# Altium schematic sheet CUP2.SchDoc(CUP2)
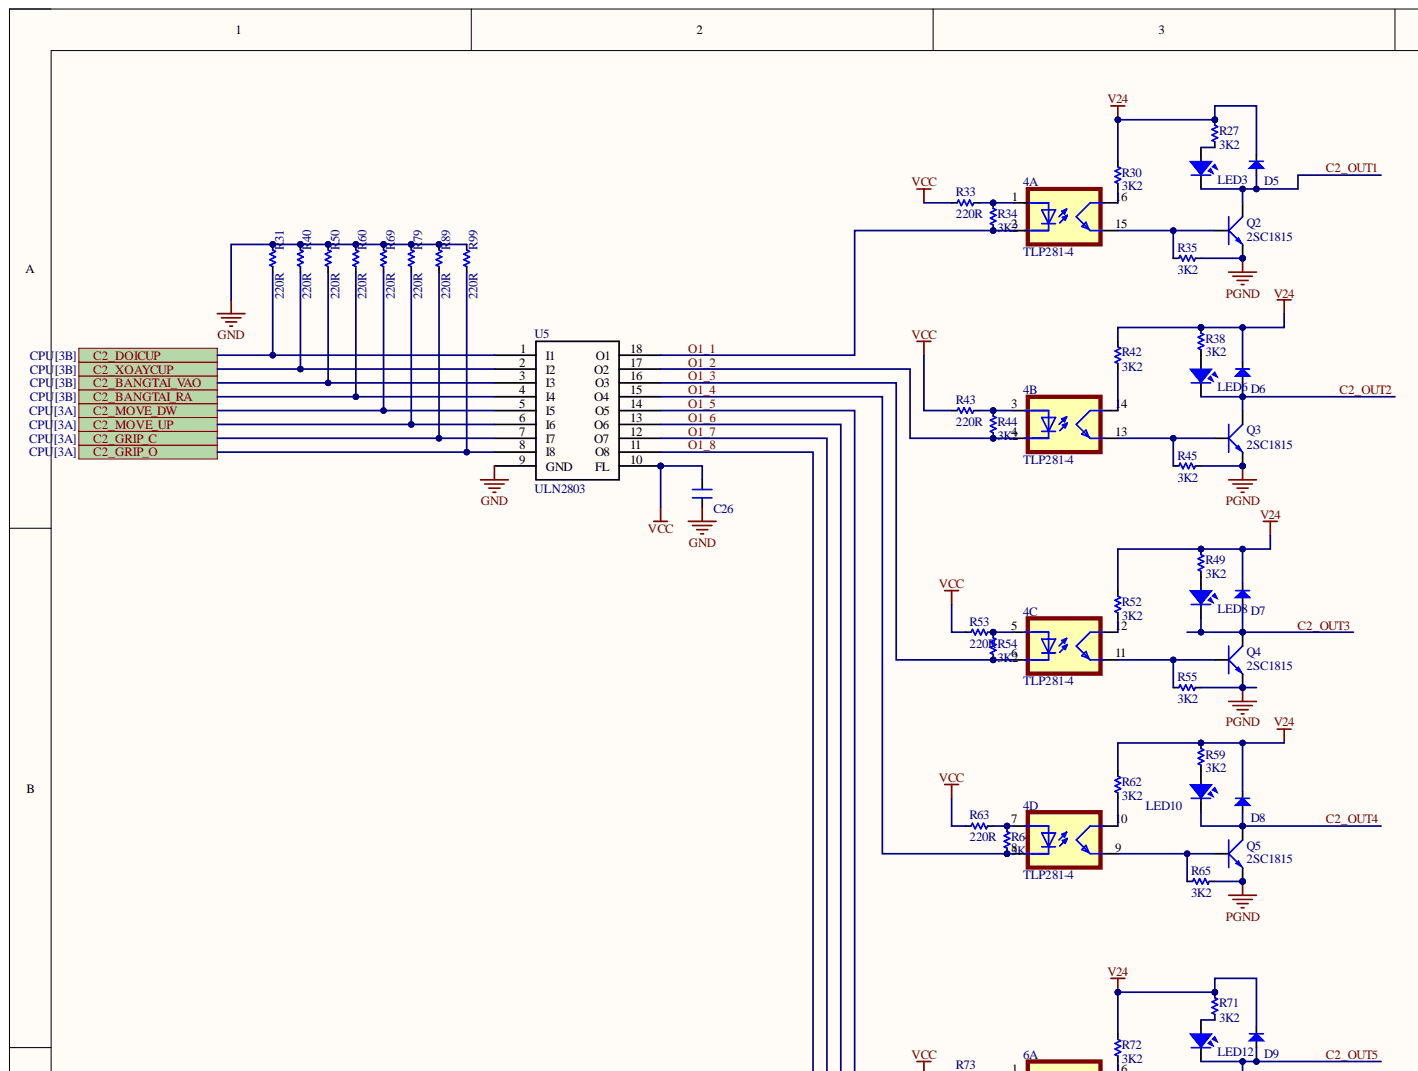
[diagram p0: CUP2.SchDoc, top left]
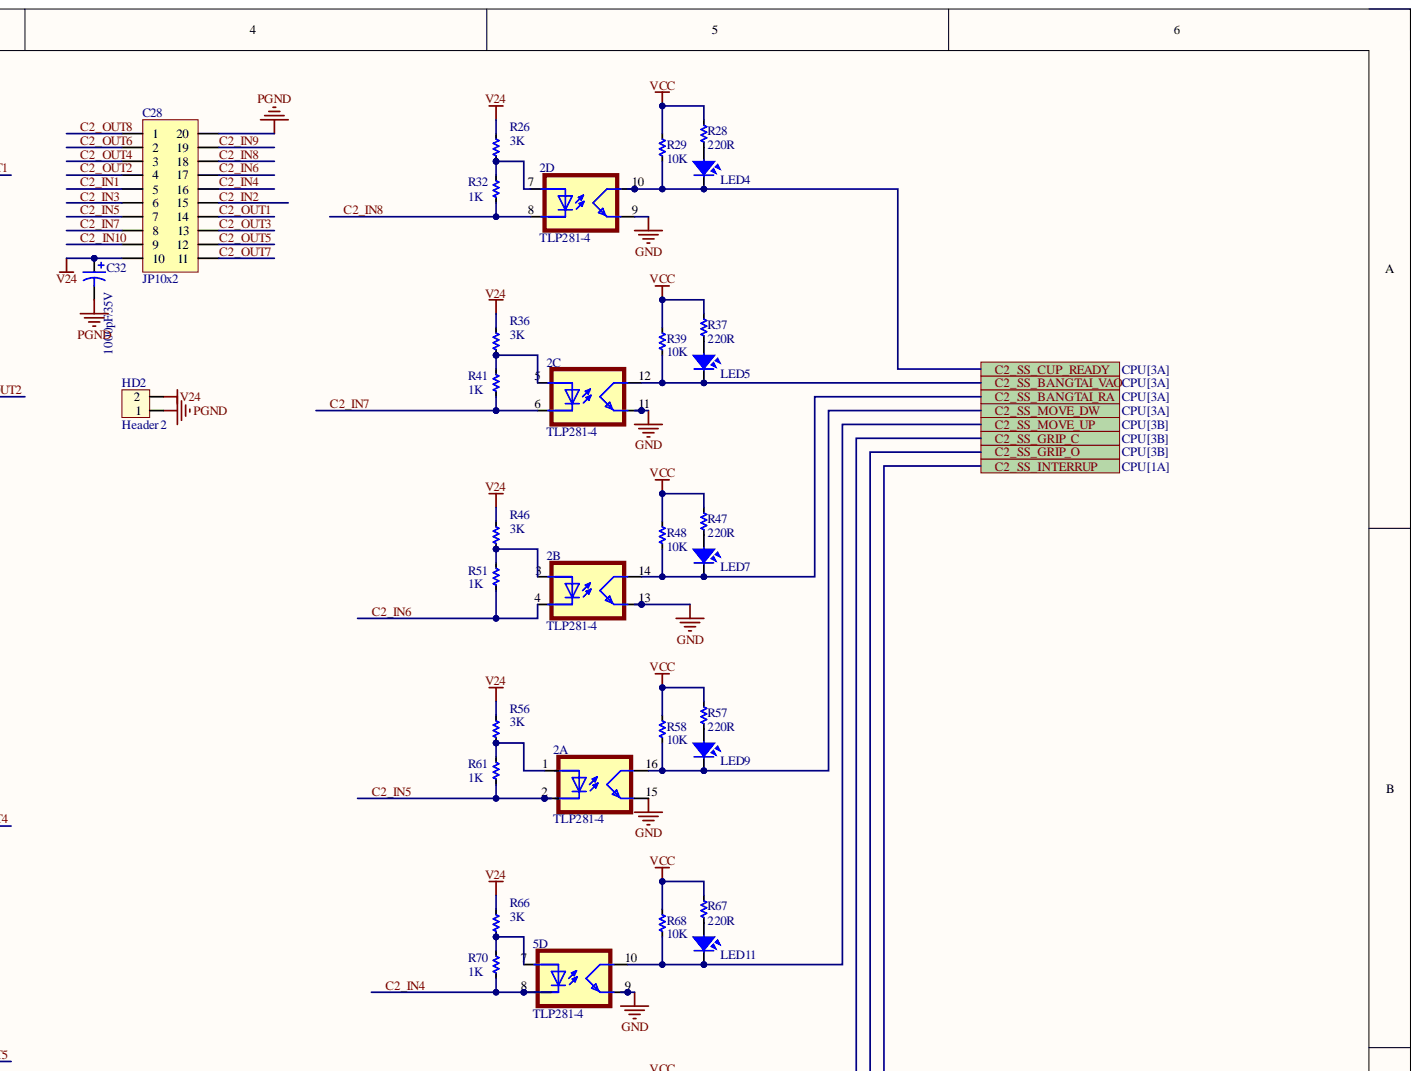
[diagram p1: CUP2.SchDoc, top right]
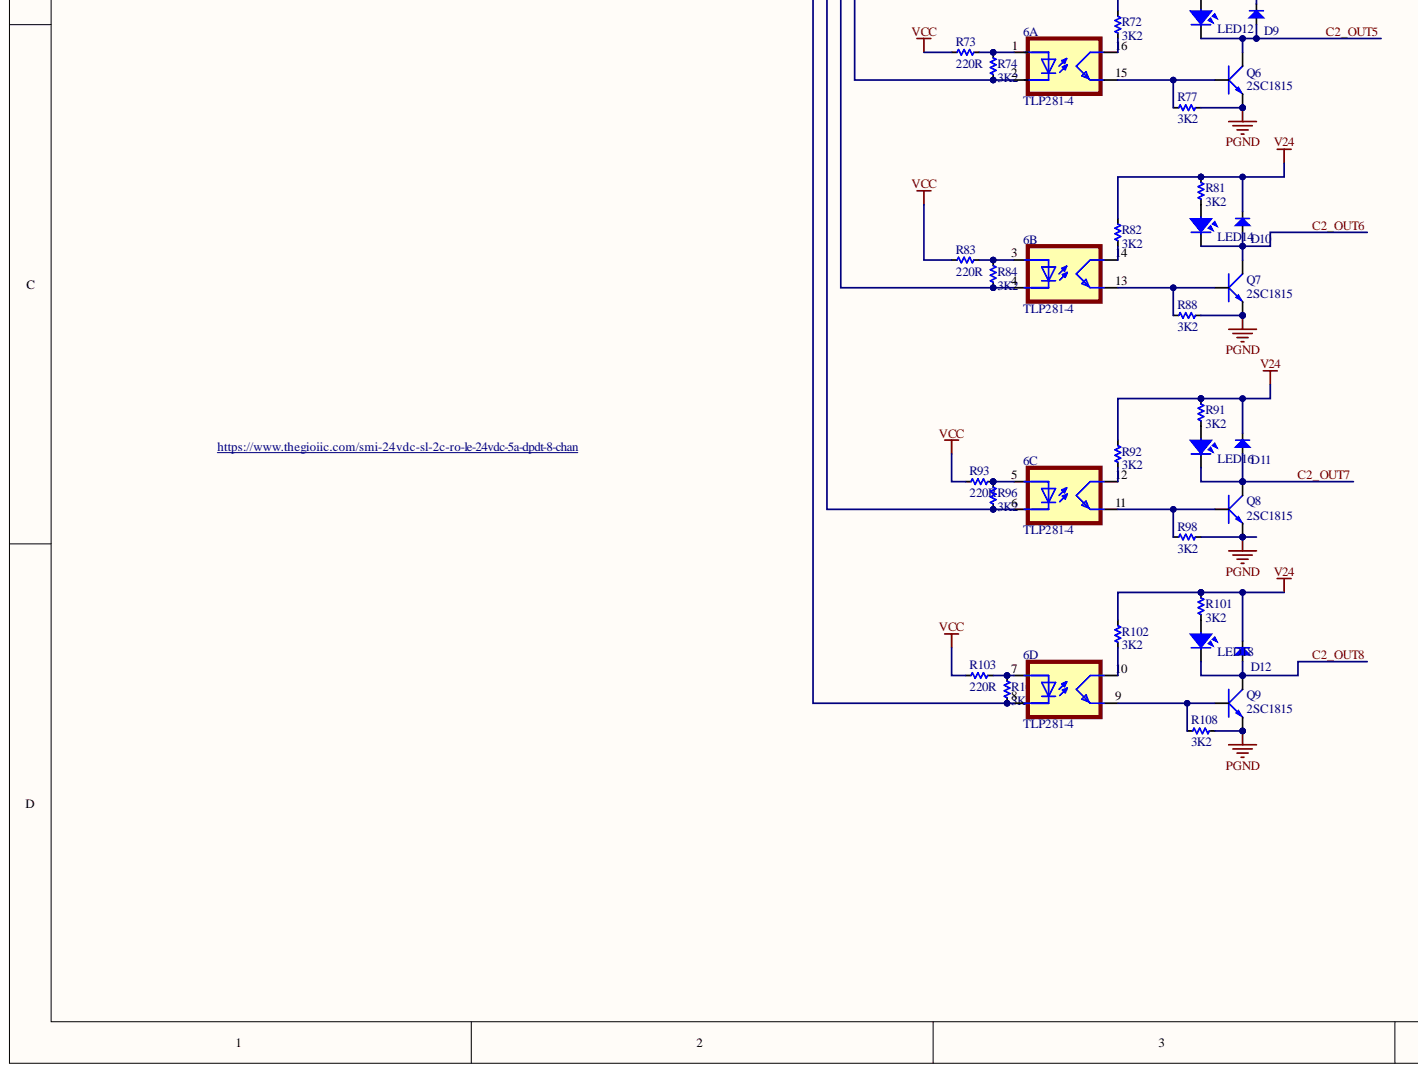
[diagram p2: CUP2.SchDoc, bottom left]
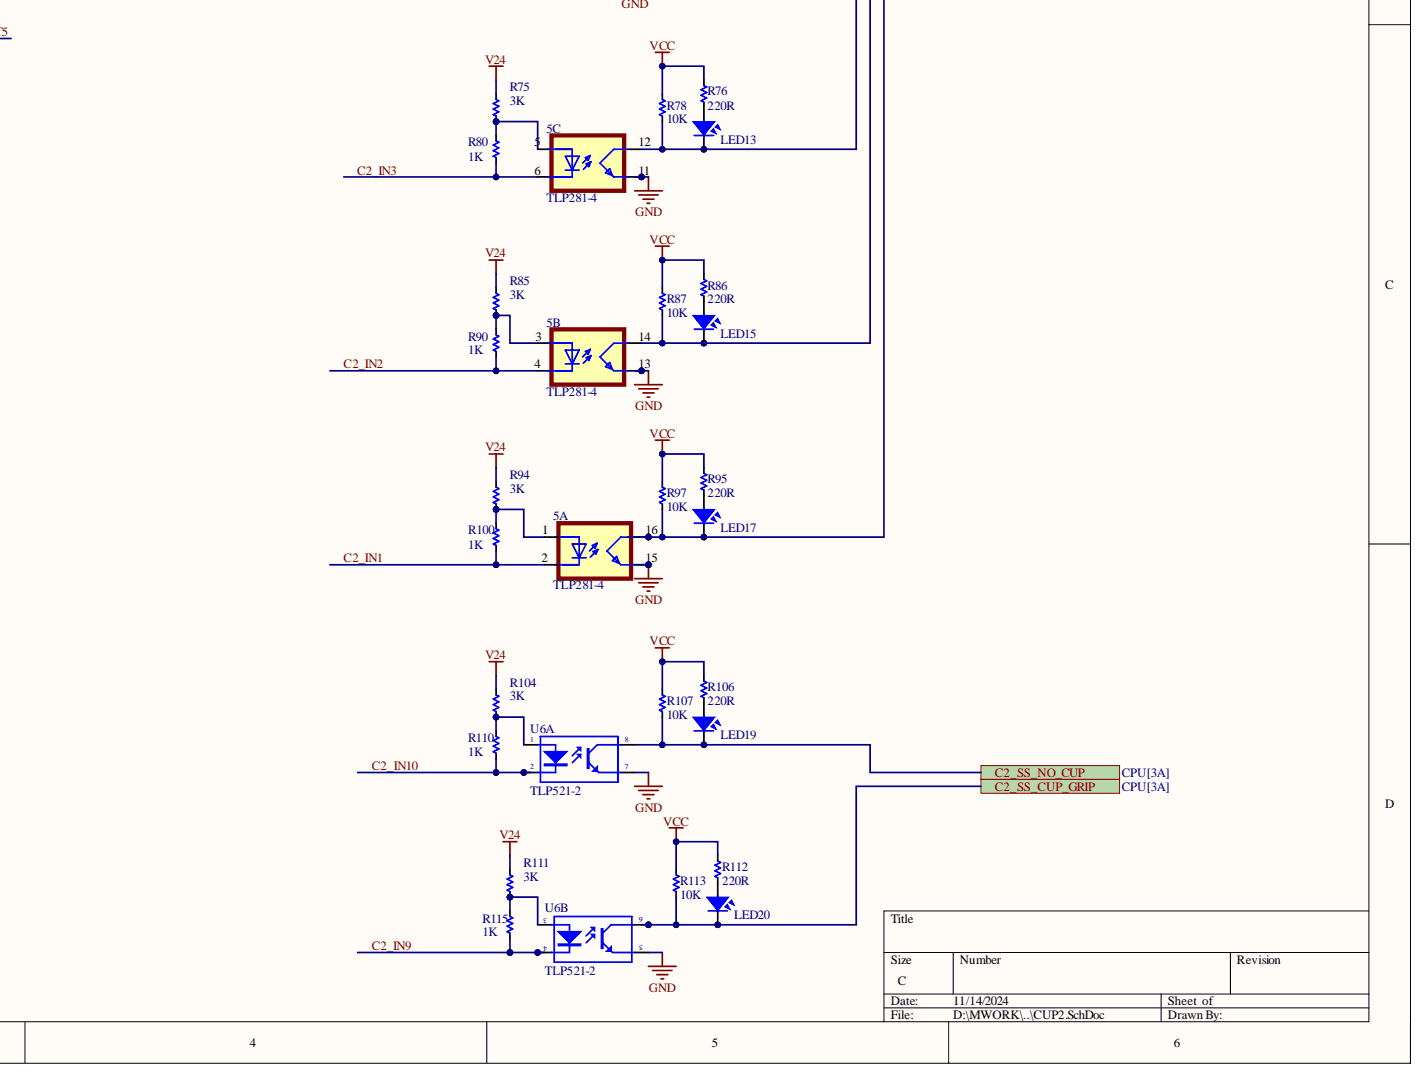
[diagram p3: CUP2.SchDoc, bottom right]

## Parts
2  TLP281-4  [SOP-16]  (parts A,B,C,D)
4  TLP281-4  [SOP-16]  (parts A,B,C,D)
5  TLP281-4  [SOP-16]  (parts A,B,C,D)
6  TLP281-4  [SOP-16]  (parts A,B,C,D)
C26  100nF  [CN-SMD-0805]  (value not drawn)
C28  JP10x2  [Connecter 5569]
C32  Cap Pol3  [CP2/5]  (value not drawn)
D5  Diode  [DIO-SMD-106-HayDung]  (value not drawn)
D6  Diode  [DIO-SMD-106-HayDung]  (value not drawn)
D7  Diode  [DIO-SMD-106-HayDung]  (value not drawn)
D8  Diode  [DIO-SMD-106-HayDung]  (value not drawn)
D9  Diode  [DIO-SMD-106-HayDung]  (value not drawn)
D10  Diode  [DIO-SMD-106-HayDung]  (value not drawn)
D11  Diode  [DIO-SMD-106-HayDung]  (value not drawn)
D12  Diode  [DIO-SMD-106-HayDung]  (value not drawn)
HD2  Header 2  [HED2T]
LED3  LED  [LED-0805-Blue]
LED4  LED  [LED-0805-Blue]
LED5  LED  [LED-0805-Blue]
LED6  LED  [LED-0805-Blue]
LED7  LED  [LED-0805-Blue]
LED8  LED  [LED-0805-Blue]
LED9  LED  [LED-0805-Blue]
LED10  LED  [LED-0805-Blue]
LED11  LED  [LED-0805-Blue]
LED12  LED  [LED-0805-Blue]
LED13  LED  [LED-0805-Blue]
LED14  LED  [LED-0805-Blue]
LED15  LED  [LED-0805-Blue]
LED16  LED  [LED-0805-Blue]
LED17  LED  [LED-0805-Blue]
LED18  LED  [LED-0805-Blue]
LED19  LED  [LED-0805-Blue]
LED20  LED  [LED-0805-Blue]
Q2  2SC1815  [SOT23-321]
Q3  2SC1815  [SOT23-321]
Q4  2SC1815  [SOT23-321]
Q5  2SC1815  [SOT23-321]
Q6  2SC1815  [SOT23-321]
Q7  2SC1815  [SOT23-321]
Q8  2SC1815  [SOT23-321]
Q9  2SC1815  [SOT23-321]
R26  3K  [RES-SMD-1206]
R27  3K2  [RES-SMD-0805]
R28  220R  [RES-SMD-0805]
R29  10K  [RES-SMD-0805]
R30  3K2  [RES-SMD-0805]
R31  220R  [RES-SMD-0805]
R32  1K  [RES-SMD-0805]
R33  220R  [RES-SMD-0805]
R34  3K2  [RES-SMD-0805]
R35  3K2  [RES-SMD-0805]
R36  3K  [RES-SMD-1206]
R37  220R  [RES-SMD-0805]
R38  3K2  [RES-SMD-0805]
R39  10K  [RES-SMD-0805]
R40  220R  [RES-SMD-0805]
R41  1K  [RES-SMD-0805]
R42  3K2  [RES-SMD-0805]
R43  220R  [RES-SMD-0805]
R44  3K2  [RES-SMD-0805]
R45  3K2  [RES-SMD-0805]
R46  3K  [RES-SMD-1206]
R47  220R  [RES-SMD-0805]
R48  10K  [RES-SMD-0805]
R49  3K2  [RES-SMD-0805]
R50  220R  [RES-SMD-0805]
R51  1K  [RES-SMD-0805]
R52  3K2  [RES-SMD-0805]
R53  220R  [RES-SMD-0805]
R54  3K2  [RES-SMD-0805]
R55  3K2  [RES-SMD-0805]
R56  3K  [RES-SMD-1206]
R57  220R  [RES-SMD-0805]
R58  10K  [RES-SMD-0805]
R59  3K2  [RES-SMD-0805]
R60  220R  [RES-SMD-0805]
R61  1K  [RES-SMD-0805]
R62  3K2  [RES-SMD-0805]
R63  220R  [RES-SMD-0805]
R64  3K2  [RES-SMD-0805]
R65  3K2  [RES-SMD-0805]
R66  3K  [RES-SMD-1206]
R67  220R  [RES-SMD-0805]
R68  10K  [RES-SMD-0805]
R69  220R  [RES-SMD-0805]
R70  1K  [RES-SMD-0805]
R71  3K2  [RES-SMD-0805]
R72  3K2  [RES-SMD-0805]
R73  220R  [RES-SMD-0805]
R74  3K2  [RES-SMD-0805]
R75  3K  [RES-SMD-1206]
R76  220R  [RES-SMD-0805]
R77  3K2  [RES-SMD-0805]
R78  10K  [RES-SMD-0805]
R79  220R  [RES-SMD-0805]
R80  1K  [RES-SMD-0805]
R81  3K2  [RES-SMD-0805]
R82  3K2  [RES-SMD-0805]
R83  220R  [RES-SMD-0805]
R84  3K2  [RES-SMD-0805]
R85  3K  [RES-SMD-1206]
R86  220R  [RES-SMD-0805]
R87  10K  [RES-SMD-0805]
R88  3K2  [RES-SMD-0805]
R89  220R  [RES-SMD-0805]
R90  1K  [RES-SMD-0805]
R91  3K2  [RES-SMD-0805]
R92  3K2  [RES-SMD-0805]
R93  220R  [RES-SMD-0805]
R94  3K  [RES-SMD-1206]
R95  220R  [RES-SMD-0805]
R96  3K2  [RES-SMD-0805]
R97  10K  [RES-SMD-0805]
R98  3K2  [RES-SMD-0805]
R99  220R  [RES-SMD-0805]
R100  1K  [RES-SMD-0805]
R101  3K2  [RES-SMD-0805]
R102  3K2  [RES-SMD-0805]
R103  220R  [RES-SMD-0805]
R104  3K  [RES-SMD-1206]
R105  3K2  [RES-SMD-0805]
R106  220R  [RES-SMD-0805]
R107  10K  [RES-SMD-0805]
R108  3K2  [RES-SMD-0805]
R110  1K  [RES-SMD-0805]
R111  3K  [RES-SMD-1206]
R112  220R  [RES-SMD-0805]
R113  10K  [RES-SMD-0805]
R115  1K  [RES-SMD-0805]
U5  ULN2803  [SO18]
U6  TLP521-2  [DIP8/W0.3]  (parts A,B)

## Ports
C2_BANGTAI_RA, C2_BANGTAI_VAO, C2_DOICUP, C2_GRIP_C, C2_GRIP_O, C2_MOVE_DW, C2_MOVE_UP, C2_SS_BANGTAI_RA, C2_SS_BANGTAI_VAO, C2_SS_CUP_GRIP, C2_SS_CUP_READY, C2_SS_GRIP_C, C2_SS_GRIP_O, C2_SS_INTERRUP, C2_SS_MOVE_DW, C2_SS_MOVE_UP, C2_SS_NO_CUP, C2_XOAYCUP

## Nets
GND: 2.9 2.11 2.13 2.15 5.9 5.11 5.13 5.15 C26.2 R31.2 R40.2 R50.2 R60.2 R69.2 R79.2 R89.2 R99.2 U5.9(GND) U6.5 U6.7
PGND: C28.20 C32.2 HD2.1 Q2.1 Q3.1 Q4.1 Q5.1 Q6.1 Q7.1 Q8.1 Q9.1 R35.1 R45.2 R55.1 R65.1 R77.1 R88.2 R98.1 R108.1
V24: C28.10 C32.1 D5.2 D6.2 D7.2 D8.2 D9.2 D10.2 D11.2 D12.2 HD2.2 R26.2 R27.1 R30.2 R36.2 R38.1 R42.2 R46.2 R49.1 R52.2 R56.2 R59.1 R62.2 R66.2 R71.1 R72.2 R75.2 R81.1 R82.2 R85.2 R91.1 R92.2 R94.2 R101.1 R102.2 R104.2 R111.2
VCC: C26.1 R28.1 R29.2 R33.1 R37.1 R39.2 R43.1 R47.1 R48.2 R53.1 R57.1 R58.2 R63.1 R67.1 R68.2 R73.1 R76.1 R78.2 R83.1 R86.1 R87.2 R93.1 R95.1 R97.2 R103.1 R106.1 R107.2 R112.1 R113.2 U5.10(FL)
C2_BANGTAI_RA: R60.1 U5.4(I4) ; port C2_BANGTAI_RA
C2_BANGTAI_VAO: R50.1 U5.3(I3) ; port C2_BANGTAI_VAO
C2_DOICUP: R31.1 U5.1(I1) ; port C2_DOICUP
C2_GRIP_C: R89.1 U5.7(I7) ; port C2_GRIP_C
C2_GRIP_O: R99.1 U5.8(I8) ; port C2_GRIP_O
C2_IN1: 5.2 C28.5 R100.2
C2_IN2: 5.4 C28.15 R90.2
C2_IN3: 5.6 C28.6 R80.2
C2_IN4: 5.8 C28.16 R70.2
C2_IN5: 2.2 C28.7 R61.2
C2_IN6: 2.4 C28.17 R51.2
C2_IN7: 2.6 C28.8 R41.2
C2_IN8: 2.8 C28.18 R32.2
C2_IN9: C28.19 R115.2 U6.4
C2_IN10: C28.9 R110.2 U6.2
C2_MOVE_DW: R69.1 U5.5(I5) ; port C2_MOVE_DW
C2_MOVE_UP: R79.1 U5.6(I6) ; port C2_MOVE_UP
C2_OUT1: C28.14 D5.1 LED3.2 Q2.2
C2_OUT2: C28.4 D6.1 LED6.2 Q3.2
C2_OUT3: C28.13 D7.1 LED8.2 Q4.2
C2_OUT4: C28.3 D8.1 LED10.2 Q5.2
C2_OUT5: C28.12 D9.1 LED12.2 Q6.2
C2_OUT6: C28.2 D10.1 LED14.2 Q7.2
C2_OUT7: C28.11 D11.1 LED16.2 Q8.2
C2_OUT8: C28.1 D12.1 LED18.2 Q9.2
C2_SS_BANGTAI_RA: 2.14 LED7.2 R48.1 ; port C2_SS_BANGTAI_RA
C2_SS_BANGTAI_VAO: 2.12 LED5.2 R39.1 ; port C2_SS_BANGTAI_VAO
C2_SS_CUP_GRIP: LED20.2 R113.1 U6.6 ; port C2_SS_CUP_GRIP
C2_SS_CUP_READY: 2.10 LED4.2 R29.1 ; port C2_SS_CUP_READY
C2_SS_GRIP_C: 5.12 LED13.2 R78.1 ; port C2_SS_GRIP_C
C2_SS_GRIP_O: 5.14 LED15.2 R87.1 ; port C2_SS_GRIP_O
C2_SS_INTERRUP: 5.16 LED17.2 R97.1 ; port C2_SS_INTERRUP
C2_SS_MOVE_DW: 2.16 LED9.2 R58.1 ; port C2_SS_MOVE_DW
C2_SS_MOVE_UP: 5.10 LED11.2 R68.1 ; port C2_SS_MOVE_UP
C2_SS_NO_CUP: LED19.2 R107.1 U6.8 ; port C2_SS_NO_CUP
C2_XOAYCUP: R40.1 U5.2(I2) ; port C2_XOAYCUP
O1_1: 4.2 R34.1 U5.18(O1)
O1_2: 4.4 R44.1 U5.17(O2)
O1_3: 4.6 R54.1 U5.16(O3)
O1_4: 4.8 R64.1 U5.15(O4)
O1_5: 6.2 R74.1 U5.14(O5)
O1_6: 6.4 R84.1 U5.13(O6)
O1_7: 6.6 R96.1 U5.12(O7)
O1_8: 6.8 R105.1 U5.11(O8)
Net2_1: 2.1 R56.1 R61.1
Net2_3: 2.3 R46.1 R51.1
Net2_5: 2.5 R36.1 R41.1
Net2_7: 2.7 R26.1 R32.1
Net4_1: 4.1 R33.2 R34.2
Net4_3: 4.3 R43.2 R44.2
Net4_5: 4.5 R53.2 R54.2
Net4_7: 4.7 R63.2 R64.2
Net4_9: 4.9 Q5.3 R65.2
Net4_10: 4.10 R62.1
Net4_11: 4.11 Q4.3 R55.2
Net4_12: 4.12 R52.1
Net4_13: 4.13 Q3.3 R45.1
Net4_14: 4.14 R42.1
Net4_15: 4.15 Q2.3 R35.2
Net4_16: 4.16 R30.1
Net5_1: 5.1 R94.1 R100.1
Net5_3: 5.3 R85.1 R90.1
Net5_5: 5.5 R75.1 R80.1
Net5_7: 5.7 R66.1 R70.1
Net6_1: 6.1 R73.2 R74.2
Net6_3: 6.3 R83.2 R84.2
Net6_5: 6.5 R93.2 R96.2
Net6_7: 6.7 R103.2 R105.2
Net6_9: 6.9 Q9.3 R108.2
Net6_10: 6.10 R102.1
Net6_11: 6.11 Q8.3 R98.2
Net6_12: 6.12 R92.1
Net6_13: 6.13 Q7.3 R88.1
Net6_14: 6.14 R82.1
Net6_15: 6.15 Q6.3 R77.2
Net6_16: 6.16 R72.1
NetLED3_1: LED3.1 R27.2
NetLED4_1: LED4.1 R28.2
NetLED5_1: LED5.1 R37.2
NetLED6_1: LED6.1 R38.2
NetLED7_1: LED7.1 R47.2
NetLED8_1: LED8.1 R49.2
NetLED9_1: LED9.1 R57.2
NetLED10_1: LED10.1 R59.2
NetLED11_1: LED11.1 R67.2
NetLED12_1: LED12.1 R71.2
NetLED13_1: LED13.1 R76.2
NetLED14_1: LED14.1 R81.2
NetLED15_1: LED15.1 R86.2
NetLED16_1: LED16.1 R91.2
NetLED17_1: LED17.1 R95.2
NetLED18_1: LED18.1 R101.2
NetLED19_1: LED19.1 R106.2
NetLED20_1: LED20.1 R112.2
NetR104_1: R104.1 R110.1 U6.1
NetR111_1: R111.1 R115.1 U6.3

## Library data of the parts
2 4-6 = TLP281-4: Optoisolator Transistor Output 2500Vrms 4 Channel 16-SOP; MF: Toshiba Semiconductor; MP: TLP 280-4; Availability: Unavailable; Component Kind: Standard; Package: SOP-16 Toshiba; Price: None; SnapEDA_Link: https://www.snapeda.com/parts/TLP%20280-4/Toshiba%20Semiconductor%20and%20Storage/view-part/108247/?ref=snap; Library Name: nhan.SchLib
C26 = CN: Value: 33pF; Component Kind: Standard; Library Name: nhan.SchLib
C28 = JP10x2: WR-PHD 2.54 mm Dual Socket Header; Manufacturer: W¨¹rth Elektronik eiSos GmbH; partNo: 61302021821; ComponentLink1 Description: Manufacturer; ComponentLink2 Description: Datasheet; NoPins: 20; Component Kind: Standard; ComponentLink1URL: http://www.we-online.com; ComponentLink2URL: http://katalog.we-online.de/em/datasheet/6130xx21821.pdf; Created Date: 29 March 2013; Created by: Karrer Zheng; Library Name: nhan.SchLib
C32 = Cap Pol3_5: Polarized Capacitor (Surface Mount); VALUE: C?; Component Kind: Standard; LatestRevisionDate: 17-Jul-2002; LatestRevisionNote: Re-released for DXP Platform.; PackageReference: C0805; Library Name: syhaunguyen.IntLib; Publisher: Altium Limited
D5-D12 = Diode: Default Diode; Code - JEDEC: DO-214-AB; Value: 1N5007; Component Kind: Standard; ComponentLink1Description: ES1J - STMBL; ComponentLink1URL: https://banlinhkien.vn/goods-8089-es1j-do214-diode-1a-600v-sma-es1j-.html; Published: 8-Jun-2000; Revision: July-2002: Re-released for DXP Platform.; Library Name: nhan.SchLib; Publisher: Altium Limited
HD2 = Hed2: Header 2 (Green); Component Kind: Standard; Value: Header 2; Library Name: syhaunguyen.IntLib
LED3-LED20 = LED SMD: Value: LED 3 - 5 ly 2 Colour; Component Kind: Standard; Library Name: LIBRARY.IntLib
Q2-Q9 = 2SC1815: NPN 600mW, ECB; Value: C1815; Component Kind: Standard; Library Name: LIBRARY.IntLib
R26 R32 R36 R41 R46 R51 R56 R61 R66 R70 R75 R80 R85 R90 R94 R100 R104 R110 R111 R115 = Res-SMD: Resistor; Manufacturer: Generic Components; Class: Passive; Component Kind: Standard; Library Name: LIBRARY.IntLib; Published: 08/06/2000; Publisher: Altium Hobart Technology Centre
R27-R31 R33-R35 R37-R40 R42-R45 R47-R50 R52-R55 R57-R60 R62-R65 R67-R69 R71-R74 R76-R79 R81-R84 R86-R89 R91-R93 R95-R99 R101-R103 R105-R108 R112 R113 = R: Component Kind: Standard; Library Name: nhan.SchLib
U5 = ULN2803: OCTAL HIGH CURRENT DRIVER; Manufacturer: Texas Instruments; Manufacturer Part Number: ULN2803ADWR; Number of Drivers/Receivers: 8/0; Voltage - Supply: 5V; Category: Integrated Circuits (ICs); Component Kind: Standard; Library Name: LIBRARY.IntLib; Package / Case: 18-SOIC (7.5mm Width); Packaging: Cut Tape (CT); Protocol: -; RoHS: RoHS Compliant; Supplier: Digi-Key; Supplier 1: Digi-Key; Supplier Part Number: 296-15777-1-ND; Supplier Part Number 1: 296-15777-1-ND; Type: Darlington Array
U6 = TLP521-2: Dual Opto; Component Kind: Standard; Value: TLP521-2; Library Name: syhaunguyen.IntLib
